# Altium schematic sheet 11 Camera.SchDoc
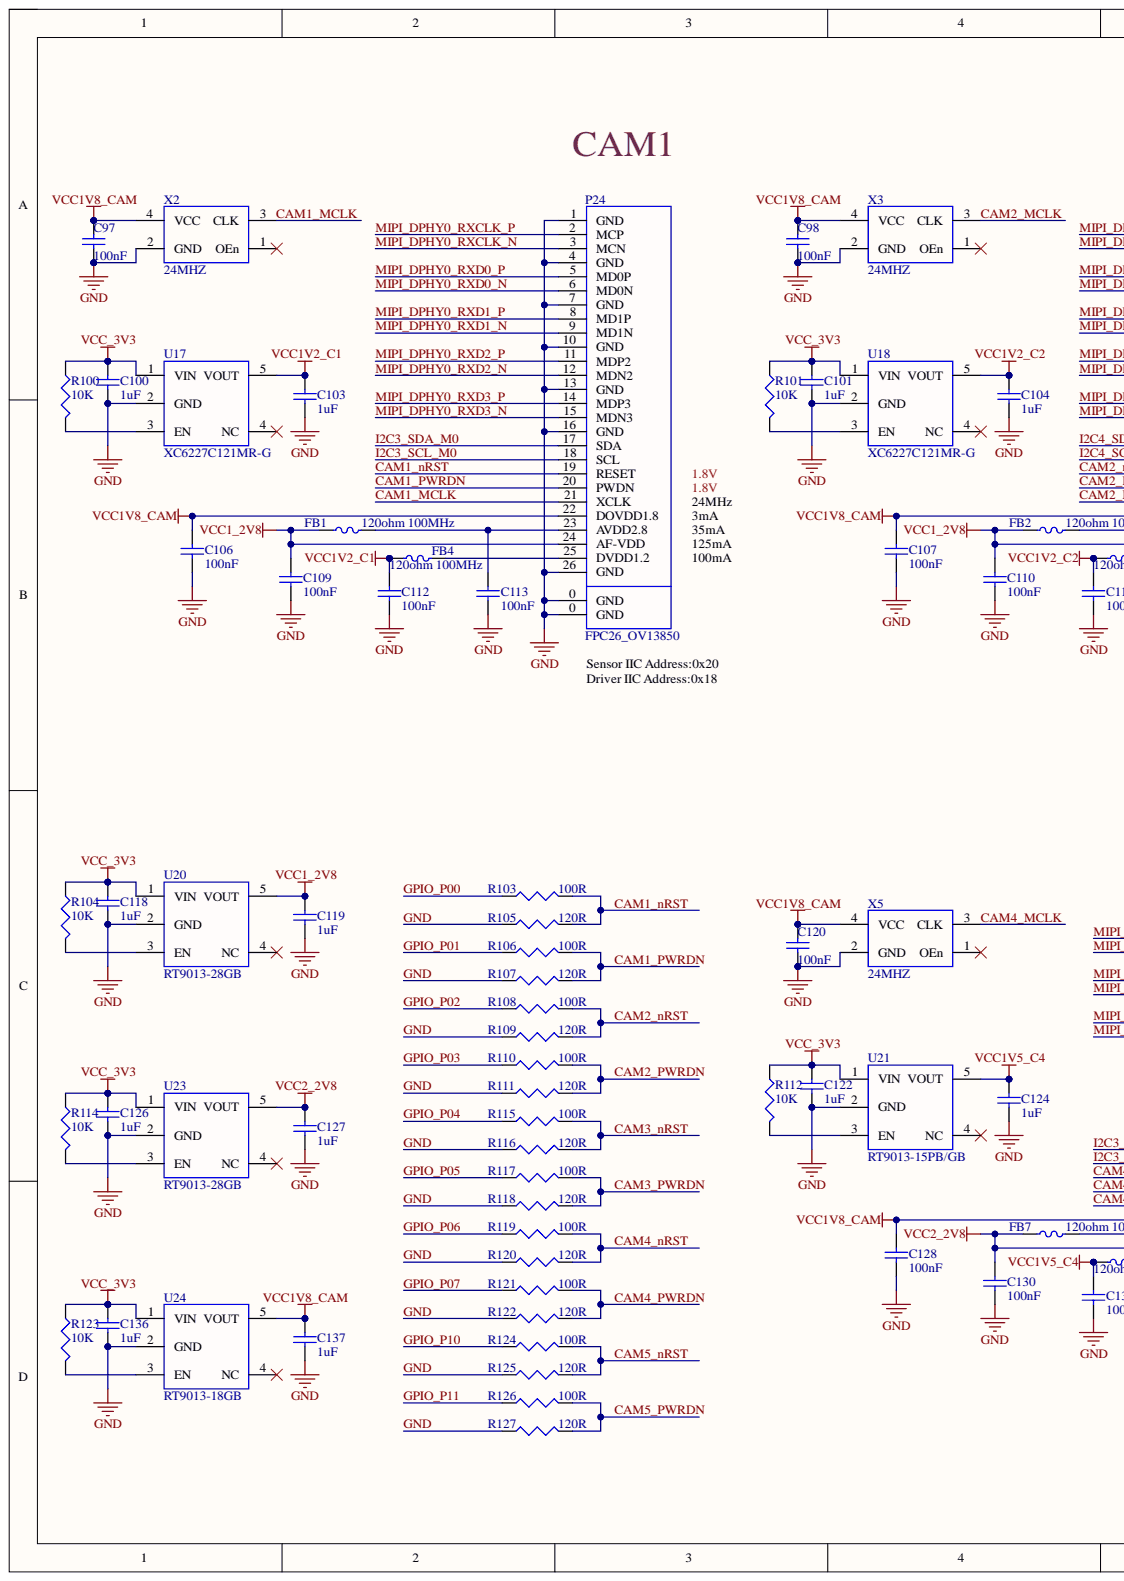
[diagram p0: 11 Camera.SchDoc, left]
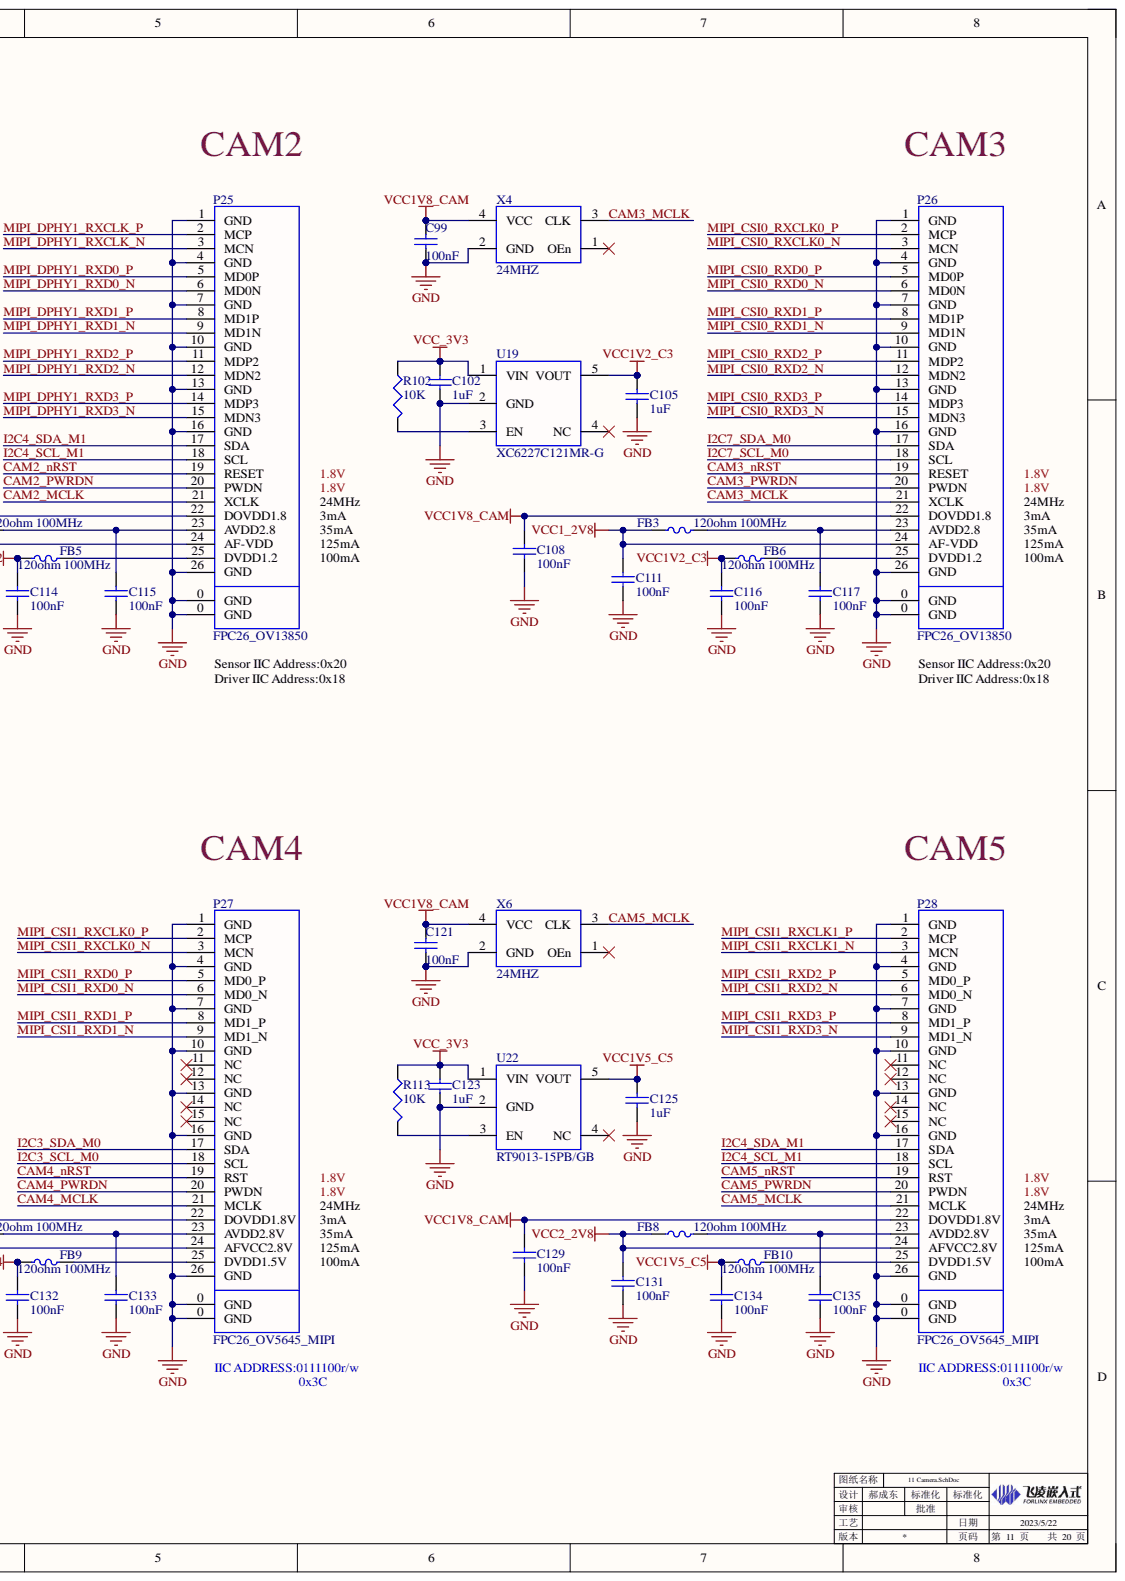
[diagram p1: 11 Camera.SchDoc, right]

## Parts
C97  100nF  [0402C]
C98  100nF  [0402C]
C99  100nF  [0402C]
C100  1uF  [0402C]
C101  1uF  [0402C]
C102  1uF  [0402C]
C103  1uF  [0402C]
C104  1uF  [0402C]
C105  1uF  [0402C]
C106  100nF  [0402C]
C107  100nF  [0402C]
C108  100nF  [0402C]
C109  100nF  [0402C]
C110  100nF  [0402C]
C111  100nF  [0402C]
C112  100nF  [0402C]
C113  100nF  [0402C]
C114  100nF  [0402C]
C115  100nF  [0402C]
C116  100nF  [0402C]
C117  100nF  [0402C]
C118  1uF  [0402C]
C119  1uF  [0402C]
C120  100nF  [0402C]
C121  100nF  [0402C]
C122  1uF  [0402C]
C123  1uF  [0402C]
C124  1uF  [0402C]
C125  1uF  [0402C]
C126  1uF  [0402C]
C127  1uF  [0402C]
C128  100nF  [0402C]
C129  100nF  [0402C]
C130  100nF  [0402C]
C131  100nF  [0402C]
C132  100nF  [0402C]
C133  100nF  [0402C]
C134  100nF  [0402C]
C135  100nF  [0402C]
C136  1uF  [0402C]
C137  1uF  [0402C]
FB1  120ohm 100MHz  [0603L]
FB2  120ohm 100MHz  [0603L]
FB3  120ohm 100MHz  [0603L]
FB4  120ohm 100MHz  [0603L]
FB5  120ohm 100MHz  [0603L]
FB6  120ohm 100MHz  [0603L]
FB7  120ohm 100MHz  [0603L]
FB8  120ohm 100MHz  [0603L]
FB9  120ohm 100MHz  [0603L]
FB10  120ohm 100MHz  [0603L]
P24  FPC26_OV13850  [FPC26]
P25  FPC26_OV13850  [FPC26]
P26  FPC26_OV13850  [FPC26]
P27  FPC26_OV5645_MIPI  [FPC26]
P28  FPC26_OV5645_MIPI  [FPC26]
R100  10K  [0402R]
R101  10K  [0402R]
R102  10K  [0402R]
R103  100R  [0402R]
R104  10K  [0402R]
R105  120R  [0402R]
R106  100R  [0402R]
R107  120R  [0402R]
R108  100R  [0402R]
R109  120R  [0402R]
R110  100R  [0402R]
R111  120R  [0402R]
R112  10K  [0402R]
R113  10K  [0402R]
R114  10K  [0402R]
R115  100R  [0402R]
R116  120R  [0402R]
R117  100R  [0402R]
R118  120R  [0402R]
R119  100R  [0402R]
R120  120R  [0402R]
R121  100R  [0402R]
R122  120R  [0402R]
R123  10K  [0402R]
R124  100R  [0402R]
R125  120R  [0402R]
R126  100R  [0402R]
R127  120R  [0402R]
U17  XC6227C121MR-G  [SOT_235]
U18  XC6227C121MR-G  [SOT_235]
U19  XC6227C121MR-G  [SOT_235]
U20  RT9013-28GB  [SOT_235]
U21  RT9013-15PB/GB  [SOT_235]
U22  RT9013-15PB/GB  [SOT_235]
U23  RT9013-28GB  [SOT_235]
U24  RT9013-18GB  [SOT_235]
X2  24MHZ  [Crystals_3225]
X3  24MHZ  [Crystals_3225]
X4  24MHZ  [Crystals_3225]
X5  24MHZ  [Crystals_3225]
X6  24MHZ  [Crystals_3225]

## Nets
VCC1_2V8: C109.2 C110.2 C111.2 C119.2 FB1.1 FB2.1 FB3.1 P24.24(AF-VDD) P25.24(AF-VDD) P26.24(AF-VDD) U20.5(VOUT)
VCC1V2_C1: C103.2 C112.2 FB4.1 U17.5(VOUT)
VCC1V2_C2: C104.2 C114.2 FB5.1 U18.5(VOUT)
VCC1V2_C3: C105.2 C116.2 FB6.1 U19.5(VOUT)
VCC1V5_C4: C124.2 C132.2 FB9.1 U21.5(VOUT)
VCC1V5_C5: C125.2 C134.2 FB10.1 U22.5(VOUT)
VCC1V8_CAM: C97.2 C98.2 C99.2 C106.2 C107.2 C108.2 C120.2 C121.2 C128.2 C129.2 C137.2 P24.22(DOVDD1.8) P25.22(DOVDD1.8) P26.22(DOVDD1.8) P27.22(DOVDD1.8V) P28.22(DOVDD1.8V) U24.5(VOUT) X2.4(VCC) X3.4(VCC) X4.4(VCC) X5.4(VCC) X6.4(VCC)
VCC2_2V8: C127.2 C130.2 C131.2 FB7.1 FB8.1 P27.24(AFVCC2.8V) P28.24(AFVCC2.8V) U23.5(VOUT)
VCC_3V3: C100.2 C101.2 C102.2 C118.2 C122.2 C123.2 C126.2 C136.2 R100.1 R101.1 R102.1 R104.1 R112.1 R113.1 R114.1 R123.1 U17.1(VIN) U18.1(VIN) U19.1(VIN) U20.1(VIN) U21.1(VIN) U22.1(VIN) U23.1(VIN) U24.1(VIN)
CAM1_MCLK: P24.21(XCLK) X2.3(CLK)
CAM1_nRST: P24.19(RESET) R103.2 R105.2
CAM1_PWRDN: P24.20(PWDN) R106.2 R107.2
CAM2_MCLK: P25.21(XCLK) X3.3(CLK)
CAM2_nRST: P25.19(RESET) R108.2 R109.2
CAM2_PWRDN: P25.20(PWDN) R110.2 R111.2
CAM3_MCLK: P26.21(XCLK) X4.3(CLK)
CAM3_nRST: P26.19(RESET) R115.2 R116.2
CAM3_PWRDN: P26.20(PWDN) R117.2 R118.2
CAM4_MCLK: P27.21(MCLK) X5.3(CLK)
CAM4_nRST: P27.19(RST) R119.2 R120.2
CAM4_PWRDN: P27.20(PWDN) R121.2 R122.2
CAM5_MCLK: P28.21(MCLK) X6.3(CLK)
CAM5_nRST: P28.19(RST) R124.2 R125.2
CAM5_PWRDN: P28.20(PWDN) R126.2 R127.2
GND: C97.1 C98.1 C99.1 C100.1 C101.1 C102.1 C103.1 C104.1 C105.1 C106.1 C107.1 C108.1 C109.1 C110.1 C111.1 C112.1 C113.1 C114.1 C115.1 C116.1 C117.1 C118.1 C119.1 C120.1 C121.1 C122.1 C123.1 C124.1 C125.1 C126.1 C127.1 C128.1 C129.1 C130.1 C131.1 C132.1 C133.1 C134.1 C135.1 C136.1 C137.1 P24.0(GND) P24.1(GND) P24.4(GND) P24.7(GND) P24.10(GND) P24.13(GND) P24.16(GND) P24.26(GND) P25.0(GND) P25.1(GND) P25.4(GND) P25.7(GND) P25.10(GND) P25.13(GND) P25.16(GND) P25.26(GND) P26.0(GND) P26.1(GND) P26.4(GND) P26.7(GND) P26.10(GND) P26.13(GND) P26.16(GND) P26.26(GND) P27.0(GND) P27.1(GND) P27.4(GND) P27.7(GND) P27.10(GND) P27.13(GND) P27.16(GND) P27.26(GND) P28.0(GND) P28.1(GND) P28.4(GND) P28.7(GND) P28.10(GND) P28.13(GND) P28.16(GND) P28.26(GND) R105.1 R107.1 R109.1 R111.1 R116.1 R118.1 R120.1 R122.1 R125.1 R127.1 U17.2(GND) U18.2(GND) U19.2(GND) U20.2(GND) U21.2(GND) U22.2(GND) U23.2(GND) U24.2(GND) X2.2(GND) X3.2(GND) X4.2(GND) X5.2(GND) X6.2(GND)
GPIO_P00: R103.1
GPIO_P01: R106.1
GPIO_P02: R108.1
GPIO_P03: R110.1
GPIO_P04: R115.1
GPIO_P05: R117.1
GPIO_P06: R119.1
GPIO_P07: R121.1
GPIO_P10: R124.1
GPIO_P11: R126.1
I2C3_SCL_M0: P24.18(SCL) P27.18(SCL)
I2C3_SDA_M0: P24.17(SDA) P27.17(SDA)
I2C4_SCL_M1: P25.18(SCL) P28.18(SCL)
I2C4_SDA_M1: P25.17(SDA) P28.17(SDA)
I2C7_SCL_M0: P26.18(SCL)
I2C7_SDA_M0: P26.17(SDA)
MIPI_CSI0_RXCLK0_N: P26.3(MCN)
MIPI_CSI0_RXCLK0_P: P26.2(MCP)
MIPI_CSI0_RXD0_N: P26.6(MD0N)
MIPI_CSI0_RXD0_P: P26.5(MD0P)
MIPI_CSI0_RXD1_N: P26.9(MD1N)
MIPI_CSI0_RXD1_P: P26.8(MD1P)
MIPI_CSI0_RXD2_N: P26.12(MDN2)
MIPI_CSI0_RXD2_P: P26.11(MDP2)
MIPI_CSI0_RXD3_N: P26.15(MDN3)
MIPI_CSI0_RXD3_P: P26.14(MDP3)
MIPI_CSI1_RXCLK0_N: P27.3(MCN)
MIPI_CSI1_RXCLK0_P: P27.2(MCP)
MIPI_CSI1_RXCLK1_N: P28.3(MCN)
MIPI_CSI1_RXCLK1_P: P28.2(MCP)
MIPI_CSI1_RXD0_N: P27.6(MD0_N)
MIPI_CSI1_RXD0_P: P27.5(MD0_P)
MIPI_CSI1_RXD1_N: P27.9(MD1_N)
MIPI_CSI1_RXD1_P: P27.8(MD1_P)
MIPI_CSI1_RXD2_N: P28.6(MD0_N)
MIPI_CSI1_RXD2_P: P28.5(MD0_P)
MIPI_CSI1_RXD3_N: P28.9(MD1_N)
MIPI_CSI1_RXD3_P: P28.8(MD1_P)
MIPI_DPHY0_RXCLK_N: P24.3(MCN)
MIPI_DPHY0_RXCLK_P: P24.2(MCP)
MIPI_DPHY0_RXD0_N: P24.6(MD0N)
MIPI_DPHY0_RXD0_P: P24.5(MD0P)
MIPI_DPHY0_RXD1_N: P24.9(MD1N)
MIPI_DPHY0_RXD1_P: P24.8(MD1P)
MIPI_DPHY0_RXD2_N: P24.12(MDN2)
MIPI_DPHY0_RXD2_P: P24.11(MDP2)
MIPI_DPHY0_RXD3_N: P24.15(MDN3)
MIPI_DPHY0_RXD3_P: P24.14(MDP3)
MIPI_DPHY1_RXCLK_N: P25.3(MCN)
MIPI_DPHY1_RXCLK_P: P25.2(MCP)
MIPI_DPHY1_RXD0_N: P25.6(MD0N)
MIPI_DPHY1_RXD0_P: P25.5(MD0P)
MIPI_DPHY1_RXD1_N: P25.9(MD1N)
MIPI_DPHY1_RXD1_P: P25.8(MD1P)
MIPI_DPHY1_RXD2_N: P25.12(MDN2)
MIPI_DPHY1_RXD2_P: P25.11(MDP2)
MIPI_DPHY1_RXD3_N: P25.15(MDN3)
MIPI_DPHY1_RXD3_P: P25.14(MDP3)
NetC113_2: C113.2 FB1.2 P24.23(AVDD2.8)
NetC115_2: C115.2 FB2.2 P25.23(AVDD2.8)
NetC117_2: C117.2 FB3.2 P26.23(AVDD2.8)
NetC133_2: C133.2 FB7.2 P27.23(AVDD2.8V)
NetC135_2: C135.2 FB8.2 P28.23(AVDD2.8V)
NetFB4_2: FB4.2 P24.25(DVDD1.2)
NetFB5_2: FB5.2 P25.25(DVDD1.2)
NetFB6_2: FB6.2 P26.25(DVDD1.2)
NetFB9_2: FB9.2 P27.25(DVDD1.5V)
NetFB10_2: FB10.2 P28.25(DVDD1.5V)
NetR100_2: R100.2 U17.3(EN)
NetR101_2: R101.2 U18.3(EN)
NetR102_2: R102.2 U19.3(EN)
NetR104_2: R104.2 U20.3(EN)
NetR112_2: R112.2 U21.3(EN)
NetR113_2: R113.2 U22.3(EN)
NetR114_2: R114.2 U23.3(EN)
NetR123_2: R123.2 U24.3(EN)

Not connected: P27 11(NC) 12(NC) 14(NC) 15(NC); P28 11(NC) 12(NC) 14(NC) 15(NC); U17 4(NC); U18 4(NC); U19 4(NC); U20 4(NC); U21 4(NC); U22 4(NC); U23 4(NC); U24 4(NC); X2 1(OEn); X3 1(OEn); X4 1(OEn); X5 1(OEn); X6 1(OEn)

## Library data of the parts
C97-C99 C106-C117 C120 C121 C128-C135 = 1110202001: Database Table Name: VALID$; 主计量单位名称: 个; 存货名称: 贴装叠层陶瓷电容; 安全库存: 2580445; 序号: 570; 旧编码: 010201001; 旧规格型号: 0402 100nF 16V ±10％ X7R; 规格型号: 0402,100nF,16V,±10％,X7R; Component Kind: Standard; LatestRevisionDate: 17-Jul-2002; LatestRevisionNote: Re-released for DXP Platform.; Library Name: Database_AD Library.DbLib; PackageDescription: Radial Cap, Thru-Hole; 2 Leads; 0.3 in Pin Spacing; PackageReference: RAD-0.3; Published: 8-Jun-2000; Signal Integrity: Cap; Simulation: CAP; Value: 100nF; 存货编码: 1110202001; Publisher: Altium Limited
C100-C105 C118 C119 C122-C127 C136 C137 = 1110202021: Database Table Name: VALID$; 存货名称: 贴装叠层陶瓷电容; 序号: 600; 规格型号: 0402,1uF,16V,±10％,X5R; Component Kind: Standard; LatestRevisionDate: 17-Jul-2002; LatestRevisionNote: Re-released for DXP Platform.; Library Name: Database_AD Library.DbLib; PackageDescription: Radial Cap, Thru-Hole; 2 Leads; 0.3 in Pin Spacing; PackageReference: RAD-0.3; Published: 8-Jun-2000; Signal Integrity: Cap; Simulation: CAP; Value: 1uF; 存货编码: 1110202021; Publisher: Altium Limited
FB1-FB10 = 1110304009: Database Table Name: VALID$; 主计量单位名称: 只; 存货名称: 磁珠; 安全库存: 148; 序号: 998; 描述栏信息: 村田; 旧编码: 010301062; 旧规格型号: 0603 120Ohm 100MHz 2A BLM18PG121SN1 村田; 规格型号: 0603,120 Ohm@100MHz,2A,BLM18PG121SN1; Component Kind: Standard; Library Name: Database_AD Library.DbLib; VALUE: 120ohm 100MHz; 存货编码: 1110304009
P24-P26 = FPC26_OV13850: 010401124; Component Kind: Standard; Library Name: Schlib1.SchLib; Value: FPC26_OV13850
P27 P28 = FPC26_OV5645_MIPI: 010401124; Database Table Name: Other$; 存货名称: FPC座; 存货编码: 1120103021; 安全库存: 1831.00; 序号: 51; 规格型号: 26P,0.5mm,下接翻盖; Component Kind: Standard; Library Name: Database_AD Library.DbLib; Value: FPC26_OV5645_MIPI; 旧编码: 010401124
R100-R102 R104 R112-R114 R123 = 1110102007: Database Table Name: VALID$; 存货名称: 贴装电阻; 序号: 17; 规格型号: 0402,10K,±5％; Component Kind: Standard; Library Name: Database_AD Library.DbLib; Signal Integrity: Res; Simulation: RESISTOR; Value: 10K; 存货编码: 1110102007
R103 R106 R108 R110 R115 R117 R119 R121 R124 R126 = 1110102052: Database Table Name: VALID$; 存货名称: 贴装电阻; 序号: 66; 规格型号: 0402,100Ω,±5％; Component Kind: Standard; Library Name: Database_AD Library.DbLib; Signal Integrity: Res; Simulation: RESISTOR; Value: 100R; 存货编码: 1110102052
R105 R107 R109 R111 R116 R118 R120 R122 R125 R127 = 1110102071: Database Table Name: VALID$; 存货名称: 贴装电阻; 序号: 101; 规格型号: 0402,120Ω,±5％; Component Kind: Standard; Library Name: Database_AD Library.DbLib; Signal Integrity: Res; Simulation: RESISTOR; Value: 120R; 存货编码: 1110102071
U17-U19 = 1110404081: Database Table Name: VALID$; 存货名称: 集成电路; 存货编码: 1110404122; Component Kind: Standard; Library Name: Database_AD Library.DbLib; Value: XC6227C121MR-G; 规格型号: XC6227C121MR-G
U20 U23 = 1110404096: Database Table Name: VALID$; 存货名称: 集成电路; 安全库存: 2574; 序号: 2092; 旧编码: 010504174; Component Kind: Standard; Library Name: Database_AD Library.DbLib; Value: RT9013-28GB; 存货编码: 1110404096; 旧规格型号: RT9013-28GB; 规格型号: RT9013-28GB
U21 U22 = 1110404081: Database Table Name: VALID$; 存货名称: 电源; 序号: 2066; Component Kind: Standard; Library Name: Database_AD Library.DbLib; Value: RT9013-15PB/GB; 存货编码: 1110404081; 规格型号: RT9013-15PB/GB
U24 = 1110404097: Database Table Name: VALID$; 存货名称: 电源; 序号: 2093; 规格型号: RT9013-18GB,SOT-23-5; Component Kind: Standard; Library Name: Database_AD Library.DbLib; Value: RT9013-18GB; 存货编码: 1110404097
X2-X6 = 1110601007: Database Table Name: VALID$; 存货名称: 贴装晶振; 序号: 3406; 规格型号: 有源,24MHZ,4P,3.2*2.5mm,3.3V,±20ppm; Component Kind: Standard; Library Name: Database_AD Library.DbLib; Value: 24MHZ; 存货编码: 1110601007
